# Altium schematic sheet ToyRaceCar_V2.SchDoc
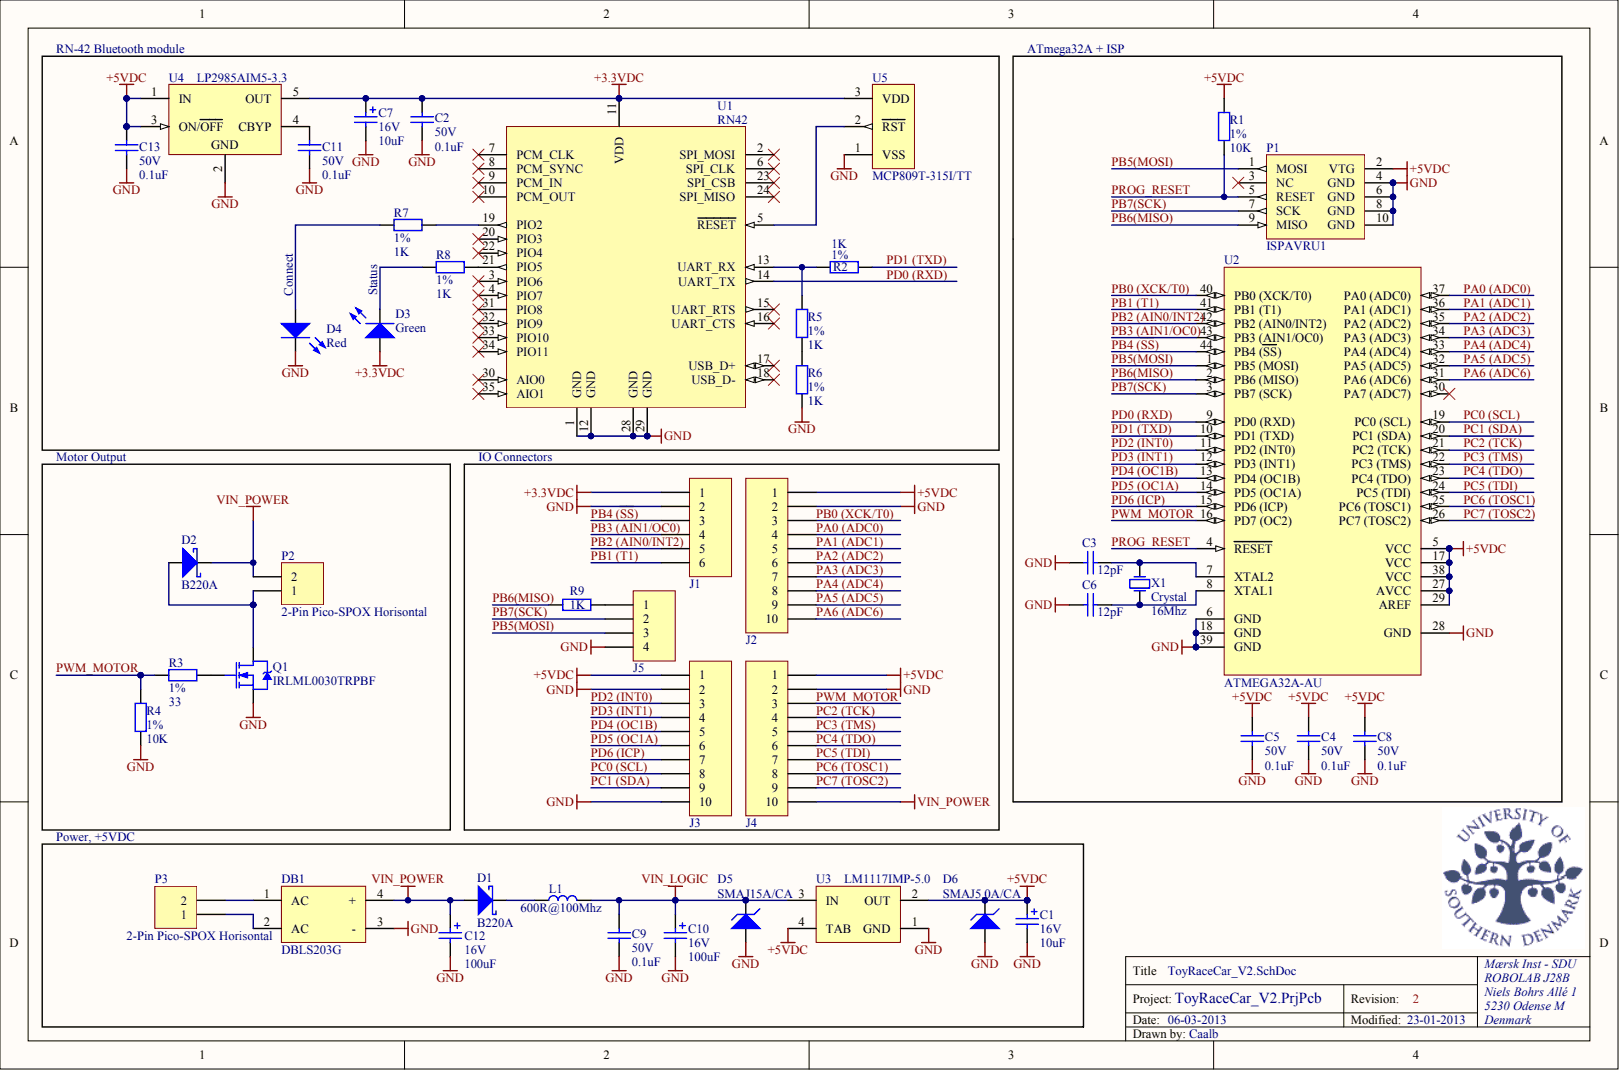
[diagram p0: ToyRaceCar_V2.SchDoc]

## Parts
C1  16V  value 10uF
C2  50V  value 0.1uF
C3  value 12pF  (hidden comment: 50V)
C4  50V  value 0.1uF
C5  50V  value 0.1uF
C6  value 12pF  (hidden comment: 50V)
C7  16V  value 10uF
C8  50V  value 0.1uF
C9  50V  value 0.1uF
C10  16V  value 100uF
C11  50V  value 0.1uF
C12  16V  value 100uF
C13  50V  value 0.1uF
D1  B220A
D2  B220A
D3  Green
D4  Red
D5  SMAJ15A/CA
D6  SMAJ5.0A/CA
DB1  DBLS203G
J1  1x6 Header  (value not drawn)
J2  1x10 Header  (value not drawn)
J3  1x10 Header  (value not drawn)
J4  1x10 Header  (value not drawn)
J5  1x4 Header  (value not drawn)
L1  600R@100Mhz
P1  ISPAVRU1
P2  2-Pin Pico-SPOX Horisontal
P3  2-Pin Pico-SPOX Horisontal
Q1  IRLML0030TRPBF
R1  1%  value 10K
R2  1%  value 1K
R3  1%  value 33
R4  1%  value 10K
R5  1%  value 1K
R6  1%  value 1K
R7  1%  value 1K
R8  1%  value 1K
R9  1%  value 1K
U1  RN42
U2  ATMEGA32A-AU
U3  LM1117IMP-5.0
U4  LP2985AIM5-3.3
U5  MCP809T-315I/TT
X1  Crystal  value 16Mhz

## Nets
+3.3VDC: C2.1 C7.1 D3.1 J1.1 U1.11(VDD) U4.5(OUT) U5.3
+5VDC: C1.1 C4.2 C5.2 C8.2 C13.2 D6.2 J2.1 J3.1 J4.1 P1.2(VTG) R1.2 U2.5(VCC) U2.17(VCC) U2.27(AVCC) U2.29(AREF) U2.38(VCC) U3.2(OUT) U3.4(TAB) U4.1(IN) U4.3(ON/OFF)
GND: C1.2 C2.2 C3.1 C4.1 C5.1 C6.1 C7.2 C8.1 C9.1 C10.2 C11.1 C12.2 C13.1 D4.2 D5.1 D6.1 DB1.3(-) J1.2 J2.2 J3.2 J3.10 J4.2 J5.4 P1.4(GND) P1.6(GND) P1.8(GND) P1.10(GND) Q1.2 R4.1 R6.2 U1.1(GND) U1.12(GND) U1.28(GND) U1.29(GND) U2.6(GND) U2.18(GND) U2.28(GND) U2.39(GND) U3.1(GND) U4.2 U5.1
VIN_LOGIC: C9.2 C10.1 D5.2 L1.2 U3.3(IN)
VIN_POWER: C12.1 D1.1 D2.2 DB1.4(+) J4.10 P2.2
PA0 (ADC0): J2.4 U2.37(PA0_(ADC0))
PA1 (ADC1): J2.5 U2.36(PA1_(ADC1))
PA2 (ADC2): J2.6 U2.35(PA2_(ADC2))
PA3 (ADC3): J2.7 U2.34(PA3_(ADC3))
PA4 (ADC4): J2.8 U2.33(PA4_(ADC4))
PA5 (ADC5): J2.9 U2.32(PA5_(ADC5))
PA6 (ADC6): J2.10 U2.31(PA6_(ADC6))
PB0 (XCK/T0): J2.3 U2.40(PB0_(XCK/T0))
PB1 (T1): J1.6 U2.41(PB1_(T1))
PB2 (AIN0/INT2): J1.5 U2.42(PB2_(AIN0/INT2))
PB3 (AIN1/OC0): J1.4 U2.43(PB3_(AIN1/OC0))
PB4 (~{SS}): J1.3 U2.44(PB4_(SS))
PB5(MOSI): J5.3 P1.1(MOSI) U2.1(PB5_(MOSI))
PB6(MISO): P1.9(MISO) R9.1 U2.2(PB6_(MISO))
PB7(SCK): J5.2 P1.7(SCK) U2.3(PB7_(SCK))
PC0 (SCL): J3.8 U2.19(PC0_(SCL))
PC1 (SDA): J3.9 U2.20(PC1_(SDA))
PC2 (TCK): J4.4 U2.21(PC2_(TCK))
PC3 (TMS): J4.5 U2.22(PC3_(TMS))
PC4 (TDO): J4.6 U2.23(PC4_(TDO))
PC5 (TDI): J4.7 U2.24(PC5_(TDI))
PC6 (TOSC1): J4.8 U2.25(PC6_(TOSC1))
PC7 (TOSC2): J4.9 U2.26(PC7_(TOSC2))
PD0 (RXD): U1.14(UART_TX) U2.9(PD0_(RXD))
PD1 (TXD): R2.1 U2.10(PD1_(TXD))
PD2 (INT0): J3.3 U2.11(PD2_(INT0))
PD3 (INT1): J3.4 U2.12(PD3_(INT1))
PD4 (OC1B): J3.5 U2.13(PD4_(OC1B))
PD5 (OC1A): J3.6 U2.14(PD5_(OC1A))
PD6 (ICP): J3.7 U2.15(PD6_(ICP))
PROG_RESET: P1.5(RESET) R1.1 U2.4(RESET)
PWM_MOTOR: J4.3 R3.2 R4.2 U2.16(PD7_(OC2))
NetC3_2: C3.2 U2.7(XTAL2) X1.2
NetC6_2: C6.2 U2.8(XTAL1) X1.1
NetC11_2: C11.2 U4.4(CBYP)
NetD1_2: D1.2 L1.1
NetD2_1: D2.1 P2.1 Q1.3
NetD3_2: D3.2 R8.1
NetD4_1: D4.1 R7.1
NetDB1_1: DB1.1(AC) P3.2
NetDB1_2: DB1.2(AC) P3.1
NetJ5_1: J5.1 R9.2
NetQ1_1: Q1.1 R3.1
NetR2_2: R2.2 R5.1 U1.13(UART_RX)
NetR5_2: R5.2 R6.1
NetR7_2: R7.2 U1.19(PIO2)
NetR8_2: R8.2 U1.21(PIO5)
NetU1_5: U1.5(RESET) U5.2

Not connected: P1 3(NC); U1 2(SPI_MOSI) 3(PIO6) 4(PIO7) 6(SPI_CLK) 7(PCM_CLK) 8(PCM_SYNC) 9(PCM_IN) 10(PCM_OUT) 15(UART_RTS) 16(UART_CTS) 17(USB_D+) 18(USB_D-) 20(PIO3) 22(PIO4) 23(SPI_CSB) 24(SPI_MISO) 30(AIO0) 31(PIO8) 32(PIO9) 33(PIO10) 34(PIO11) 35(AIO1); U2 30(PA7_(ADC7))

## Library data of the parts
C1 C2 C4 C5 C7-C9 C11 C13 D3 D4 P1 R1 R2 R4-R6 R8 R9 = (no symbol name): Lager: Yes
  D3 D4 P1 R1 R2 R4-R6 R8 R9: PN RS: *; PN Farnell: *; PN Digikey: *
C3 C6 = (no symbol name): Lager: No; PN RS: 211-3120
C10 C12 = (no symbol name): Lager: No; PN RS: 684-4477
D1 D2 = (no symbol name): PN RS: 700-9956; Lager: No; PN Farnell: 1858604; PN Digikey: B220A-FDICT-ND; Supplier 1: Digi-Key; ComponentLink1URL: http://www.diodes.com/datasheets/ds13004.pdf; ComponentLink1Description: http://www.diodes.com/datasheets/ds13004.pdf; Supplier Part Number 1: B220A-FDICT-ND
D5 = (no symbol name): PN RS: 687-3154; Lager: No; PN Farnell: *; PN Digikey: *; ComponentLink1URL: http://www.st.com/internet/com/TECHNICAL_RESOURCES/TECHNICAL_LITERATURE/DATASHEET/CD00001333.pdf; ComponentLink1Description: http://www.st.com/internet/com/TECHNICAL_RESOURCES/TECHNICAL_LITERATURE/DATASHEET/CD00001333.pdf; Supplier 1: Farnell; Supplier Part Number 1: 1749306
D6 = (no symbol name): PN RS: 767-3759; Lager: No; PN Farnell: *; PN Digikey: *; ComponentLink1URL: http://www.st.com/internet/com/TECHNICAL_RESOURCES/TECHNICAL_LITERATURE/DATASHEET/CD00001333.pdf; ComponentLink1Description: http://www.st.com/internet/com/TECHNICAL_RESOURCES/TECHNICAL_LITERATURE/DATASHEET/CD00001333.pdf; Supplier 1: Farnell; Supplier Part Number 1: 1749306
DB1 = (no symbol name): PN RS: 652-6356; Lager: No; PN Farnell: 1299298
J1-J5 Q1 U1 U5 = (no symbol name): Lager: No
  J1-J5: PN Farnell: *; PN RS: *; PN Digikey: *
  Q1: PN RS: *; PN Farnell: 1791366; PN Digikey: IRLML0030TRPBFCT-ND; Supplier 1: Farnell; ComponentLink1URL: http://www.irf.com/product-info/datasheets/data/irLML0030pbf.pdf; ComponentLink1Description: http://www.irf.com/product-info/datasheets/data/irLML0030pbf.pdf; Supplier Part Number 1: 1791366
  U1: PN RS: *; PN Farnell: *; PN Digikey: *; PN Mouser: 765-RN-42; Supplier 1: Mouser; Supplier Part Number 1: 765-RN-42
  U5: Published: 29-Jan-2009; DatasheetVersion: revC, 2001; PackageVersion: revAW, Oct-2008; PackageReference: SOT-23-TT3; PackageDescription: 3-Lead Plastic Small Outline Transistor (TT) [SOT-23]; Code_IPC: SOT23-3; ComponentLink1Description: Manufacturer Link; ComponentLink1URL: http://www.microchip.com; ComponentLink2Description: Datasheet; ComponentLink2URL: http://ww1.microchip.com/downloads/en/DeviceDoc/11194c.pdf; ComponentLink3Description: Packaging Specification; ComponentLink3URL: http://ww1.microchip.com/downloads/en/PackagingSpec/00049AW.pdf; PN Farnell: 1084257; Publisher: Altium Limited
L1 = (no symbol name): Value: 330R@100Mhz; Lager: No; PN RS: 724-1545; PN Farnell: 1515663; ComponentLink1URL: http://www.farnell.com/datasheets/5160.pdf; ComponentLink1Description: http://www.farnell.com/datasheets/5160.pdf; Supplier 1: Farnell; Supplier Part Number 1: 1515663
P2 P3 = (no symbol name): Lager: No; PN RS: 670-6492; PN Farnell: *; PN Digikey: *; ComponentLink1URL: http://www.farnell.com/cad/491865.pdf; ComponentLink1Description: http://www.farnell.com/cad/491865.pdf
R3 = (no symbol name): Lager: Yes; Value: 33; PN RS: *; PN Farnell: *; PN Digikey: *
R7 = (no symbol name): Lager: Yes; Value: 1K; PN RS: *; PN Farnell: *; PN Digikey: *
U2 = (no symbol name): PN RS: 696-3477; Lager: No; ComponentLink1URL: http://www.atmel.com/dyn/resources/prod_documents/doc8155.pdf; ComponentLink1Description: http://www.atmel.com/dyn/resources/prod_documents/doc8155.pdf; Supplier 1: Digi-Key; Supplier Part Number 1: ATMEGA32A-AU-ND; PN Digikey: ATMEGA32A-AU-ND
U3 = (no symbol name): Manufacturer: National Semiconductor; CompType: LDO IC; PackageTopMarking: XYTT*N06B; PowerWise: N; PN RS: 535-8641; Lager: No; Published: 4-Dec-2008; DatasheetVersion: Apr-2006; PackageVersion: revB, Apr-2003; PackageReference: MP04A; PackageDescription: Molded SOT-223, 6.50 x 3.56 x 1.6mm Body, 4 Lead, 2.3mm Pitch; PartNumber: LM1117IMP-5.0; RoHS: O; PinCount: 4; DigiKeyPartNumber: LM1117IMP-5.0CT-ND; Code_JEDEC: TO-261-AA; Code_IPC: SOT223-4; ComponentLink1Description: LM1117 Datasheet; ComponentLink1URL: http://www.national.com/ds/LM/LM1117.pdf; ComponentLink2Description: LM1117 Web Page; ComponentLink2URL: http://www.national.com/pf/LM/LM1117.html; ComponentLink3Description: MP04A Package Page; ComponentLink3URL: http://www.national.com/packaging/folders/mp04a.html; Publisher: Altium Limited
U4 = (no symbol name): Lager: No; PN RS: 652-0033; PN Farnell: 1053672; ComponentLink1URL: http://www.farnell.com/datasheets/87779.pdf; ComponentLink1Description: http://www.farnell.com/datasheets/87779.pdf
X1 = (no symbol name): Lager: No; PN RS: 478-9505
